# Altium schematic sheet Microcontroller.SchDoc
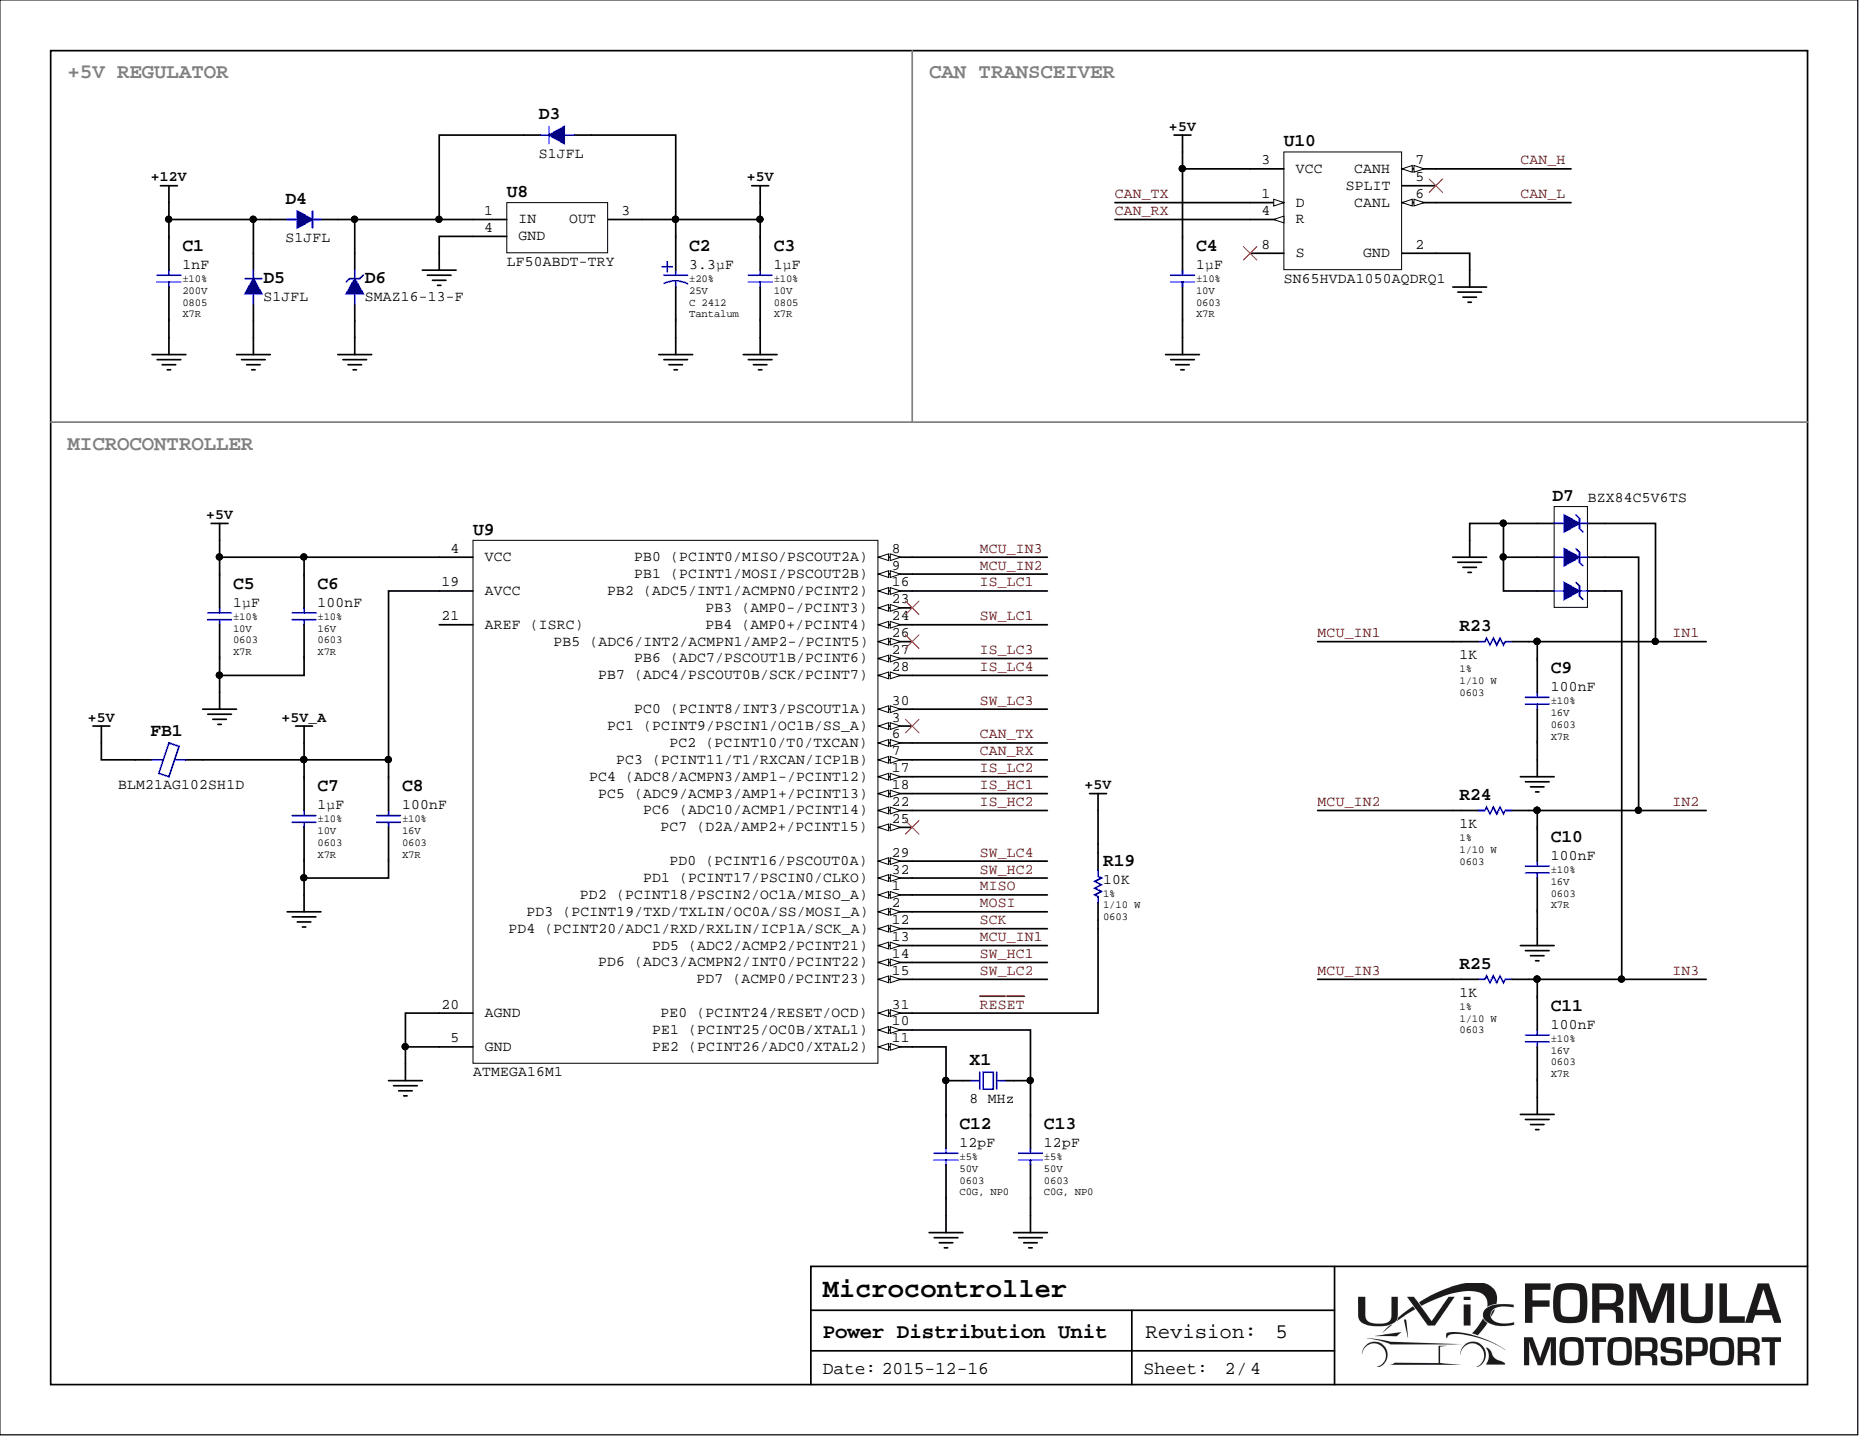
[diagram p0: Microcontroller.SchDoc]

## Parts
C1  1nF  Package: 0805  Temperature Coefficient: X7R  Tolerance: ±10%  Voltage: 200V  [CAP-0805-M]
C2  3.3µF  Package: C 2412  Tolerance: ±20%  Type: Tantalum  Voltage: 25V  [CAP-TANT-C-M]
C3  1µF  Package: 0805  Temperature Coefficient: X7R  Tolerance: ±10%  Voltage: 10V  [CAP-0805-M]
C4  1µF  Package: 0603  Temperature Coefficient: X7R  Tolerance: ±10%  Voltage: 10V  [CAP-0603-M]
C5  1µF  Package: 0603  Temperature Coefficient: X7R  Tolerance: ±10%  Voltage: 10V  [CAP-0603-M]
C6  100nF  Package: 0603  Temperature Coefficient: X7R  Tolerance: ±10%  Voltage: 16V  [CAP-0603-M]
C7  1µF  Package: 0603  Temperature Coefficient: X7R  Tolerance: ±10%  Voltage: 10V  [CAP-0603-M]
C8  100nF  Package: 0603  Temperature Coefficient: X7R  Tolerance: ±10%  Voltage: 16V  [CAP-0603-M]
C9  100nF  Package: 0603  Temperature Coefficient: X7R  Tolerance: ±10%  Voltage: 16V  [CAP-0603-M]
C10  100nF  Package: 0603  Temperature Coefficient: X7R  Tolerance: ±10%  Voltage: 16V  [CAP-0603-M]
C11  100nF  Package: 0603  Temperature Coefficient: X7R  Tolerance: ±10%  Voltage: 16V  [CAP-0603-M]
C12  12pF  Package: 0603  Tolerance: ±5%  Voltage: 50V  [CAP-0603-M]
C13  12pF  Package: 0603  Temperature Coefficient: C0G, NP0  Tolerance: ±5%  Voltage: 50V  [CAP-0603-M]
D3  S1JFL  [DIOM3617X11M]
D4  S1JFL  [DIOM3617X11M]
D5  S1JFL  [DIOM3617X11M]
D6  SMAZ16-13-F  [DIOM4326X23M]
D7  BZX84C5V6TS  [SOT65P210X110-6N]
FB1  BLM21AG102SH1D  [INDC2012X11N]
R19  10K  Package: 0603  Tolerance: 1%  [RES-0603-M]
R23  1K  Tolerance: 1%  [RES-0603-M]
R24  1K  Tolerance: 1%  [RES-0603-M]
R25  1K  Tolerance: 1%  [RES-0603-M]
U8  LF50ABDT-TRY  [TO-252-3]
U9  ATMEGA16M1  [32-TQFP-7x7-0.8]
U10  SN65HVDA1050AQDRQ1  [SOIC127P600X175-8M]
X1  8 MHz  [TXC 7A]

## Nets
+5V: C2.2 C3.2 C4.2 C5.2 C6.2 D3.2 FB1.1 R19.2 U8.3 U9.4(VCC) U10.3(VCC)
+5V_A: C7.2 C8.2 FB1.2 U9.19(AVCC)
+12V: C1.2 D4.2 D5.1
GND: C1.1 C2.1 C3.1 C4.1 C5.1 C6.1 C7.1 C8.1 C9.1 C10.1 C11.1 C12.1 C13.1 D5.2 D6.2 D7.1 D7.2 D7.3 U8.4 U9.5(GND) U9.20(AGND) U10.2(GND)
CAN_H: U10.7(CANH)
CAN_L: U10.6(CANL)
CAN_RX: U9.7((PCINT11/T1/RXCAN/ICP1B)) U10.4(R)
CAN_TX: U9.6((PCINT10/T0/TXCAN)) U10.1(D)
IN1: C9.2 D7.6 R23.2
IN2: C10.2 D7.5 R24.2
IN3: C11.2 D7.4 R25.2
IS_HC1: U9.18((ADC9/ACMP3/AMP1+/PCINT13))
IS_HC2: U9.22((ADC10/ACMP1/PCINT14))
IS_LC1: U9.16((ADC5/INT1/ACMPN0/PCINT2))
IS_LC2: U9.17((ADC8/ACMPN3/AMP1-/PCINT12))
IS_LC3: U9.27((ADC7/PSCOUT1B/PCINT6))
IS_LC4: U9.28((ADC4/PSCOUT0B/SCK/PCINT7))
MCU_IN1: R23.1 U9.13((ADC2/ACMP2/PCINT21))
MCU_IN2: R24.1 U9.9((PCINT1/MOSI/PSCOUT2B))
MCU_IN3: R25.1 U9.8((PCINT0/MISO/PSCOUT2A))
MISO: U9.1((PCINT18/PSCIN2/OC1A/MISO_A))
MOSI: U9.2((PCINT19/TXD/TXLIN/OC0A/SS/MOSI_A))
~{RESET}: R19.1 U9.31((PCINT24/RESET/OCD))
SCK: U9.12((PCINT20/ADC1/RXD/RXLIN/ICP1A/SCK_A))
SW_HC1: U9.14((ADC3/ACMPN2/INT0/PCINT22))
SW_HC2: U9.32((PCINT17/PSCIN0/CLKO))
SW_LC1: U9.24((AMP0+/PCINT4))
SW_LC2: U9.15((ACMP0/PCINT23))
SW_LC3: U9.30((PCINT8/INT3/PSCOUT1A))
SW_LC4: U9.29((PCINT16/PSCOUT0A))
NetC12_2: C12.2 U9.11((PCINT26/ADC0/XTAL2)) X1.2
NetC13_2: C13.2 U9.10((PCINT25/OC0B/XTAL1)) X1.1
NetD3_1: D3.1 D4.1 D6.1 U8.1

Not connected: U9 3((PCINT9/PSCIN1/OC1B/SS_A)) 21(AREF) 23((AMP0-/PCINT3)) 25((D2A/AMP2+/PCINT15)) 26((ADC6/INT2/ACMPN1/AMP2-/PCINT5)); U10 5(SPLIT) 8(S)

## Library data of the parts
C1 = CC0805KRX7RABB102: Manufacturer: Yageo; Manufacturer Series: CC; Database Table Name: Ceramic - 0805$; Component Kind: Standard; Component Type: Standard; Library Name: Capacitors.DbLib; Part Number: CC0805KRX7RABB102; Supplier 1: Digi-Key; Supplier Part Number 1: 311-1469-1-ND; Temperature Range: -55°C ~ 125°C; Value: 1nF
C2 = T491C335M025AT: Manufacturer: Kemet; Manufacturer Series: T491; Database Table Name: Tantalum - C$; ESR: 2.5 Ohm; Component Kind: Standard; Component Type: Standard; Library Name: Capacitors.DbLib; Part Number: T491C335M025AT; Supplier 1: Digi-Key; Supplier Part Number 1: 399-10167-1-ND; Temperature Range: -55°C ~ 125°C; Value: 3.3µF
C3 = CC0805KKX7R6BB105: Manufacturer: Yageo; Manufacturer Series: CC; Database Table Name: Ceramic - 0805$; Component Kind: Standard; Component Type: Standard; Library Name: Capacitors.DbLib; Part Number: CC0805KKX7R6BB105; Supplier 1: Digi-Key; Supplier Part Number 1: 311-1458-1-ND; Temperature Range: -55°C ~ 125°C; Value: 1µF
C4 C5 C7 = CC0603KRX7R6BB105: Manufacturer: Yageo; Manufacturer Series: CC; Database Table Name: Ceramic - 0603$; Component Kind: Standard; Component Type: Standard; Library Name: Capacitors.DbLib; Part Number: CC0603KRX7R6BB105; Supplier 1: Digi-Key; Supplier Part Number 1: 311-1796-1-ND; Temperature Range: -55°C ~ 125°C; Value: 1µF
C6 C8-C11 = CC0603KPX7R7BB104: Manufacturer: Yageo; Manufacturer Series: CC; Database Table Name: Ceramic - 0603$; Component Kind: Standard; Component Type: Standard; Library Name: Capacitors.DbLib; Part Number: CC0603KPX7R7BB104; Supplier 1: Digi-Key; Supplier Part Number 1: 311-1335-1-ND; Temperature Range: -55°C ~ 125°C; Value: 100nF
C12 = CC0603JRNPO9BN120: Manufacturer: Yageo; Manufacturer Series: CC; Database Table Name: Ceramic - 0603$; Temperature Coefficient: C0G, NP0; Component Kind: Standard; Component Type: Standard; Library Name: Capacitors.DbLib; Part Number: CC0603JRNPO9BN120; Supplier 1: Digi-Key; Supplier Part Number 1: 311-1059-1-ND; Temperature Range: -55°C ~ 125°C; Value: 12pF
C13 = CC0603JRNPO9BN120: Manufacturer: Yageo; Manufacturer Series: CC; Database Table Name: Ceramic - 0603$; Component Kind: Standard; Component Type: Standard; Library Name: Capacitors.DbLib; Part Number: CC0603JRNPO9BN120; Supplier 1: Digi-Key; Supplier Part Number 1: 311-1059-1-ND; Temperature Range: -55°C ~ 125°C; Value: 12pF
R19 = RC0603FR-0710KL: Manufacturer: Yageo; Manufacturer Series: RC; Database Table Name: 0603$; Power: 1/10 W; Temperature Coefficient: ±100ppm/°C; Component Kind: Standard; Component Type: Standard; Library Name: Resistors.DbLib; Part Number: RC0603FR-0710KL; Supplier 1: Digi-Key; Supplier Part Number 1: 311-10.0KHRCT-ND; Temperature Range: -55°C ~ 155°C; Value: 10K
R23-R25 = RC0603FR-071KL: Manufacturer: Yageo; Manufacturer Series: RC; Database Table Name: 0603$; Power: 1/10 W; Temperature Coefficient: ±100ppm/°C; Component Kind: Standard; Component Type: Standard; Library Name: Resistors.DbLib; Package: 0603; Part Number: RC0603FR-071KL; Supplier 1: Digi-Key; Supplier Part Number 1: 311-1.00KHRCT-ND; Temperature Range: -55°C ~ 155°C; Value: 1K
U9 = ATMEGA16M1-15AZ: Component Kind: Standard; Description: ATMEGA16M1; Supplier 1: Digi-Key; Supplier 2: Mouser; Supplier Part Number 1: ATMEGA16M1-15AZCT-ND; Supplier Part Number 2: 556-ATMEGA16M1-15AZ; Library Name: UV16 PDU.SchLib
U10 = SN65HVDA1050AQDRQ1: CAN transceiver; Component Kind: Standard; Supplier 1: Digi-Key; Supplier Part Number 1: 296-25836-1-ND; Library Name: UV16 PDU.SchLib
X1 = 7A-8.000MAAE-T: SMD Crystal Oscillator 12 pF; Load Capacitance: 12pF; Value: 8MHz; Component Kind: Standard; Supplier 1: Digi-Key; Supplier Part Number 1: 887-1740-1-ND; Library Name: UV16 PDU.SchLib
D3-D5 = S1JFL: Component Kind: Standard; Supplier 1: Digi-Key; Supplier Part Number 1: ES1JFLCT-ND; Library Name: UV16 PDU.SchLib
D6 = SMAZ16-13-F: Component Kind: Standard; Supplier 1: Digi-Key; Supplier Part Number 1: SMAZ16-FDICT-ND; Library Name: UV16 PDU.SchLib
D7 = BZX84C5V6TS: Component Kind: Standard; Supplier 1: Digi-Key; Supplier Part Number 1: BZX84C5V6TS-FDICT-ND; Library Name: UV16 PDU.SchLib
FB1 = BLM21AG102SH1D: Component Kind: Standard; Supplier 1: Digi-Key; Supplier Part Number 1: 490-10664-1-ND; Library Name: UV16 PDU.SchLib
U8 = LF50ABDT-TRY: Component Kind: Standard; Supplier 1: Digi-Key; Supplier Part Number 1: 497-10199-1-ND; Library Name: UV16 PDU.SchLib
